# Altium schematic sheet DE-220124_02.SchDoc(DE-220124_02)
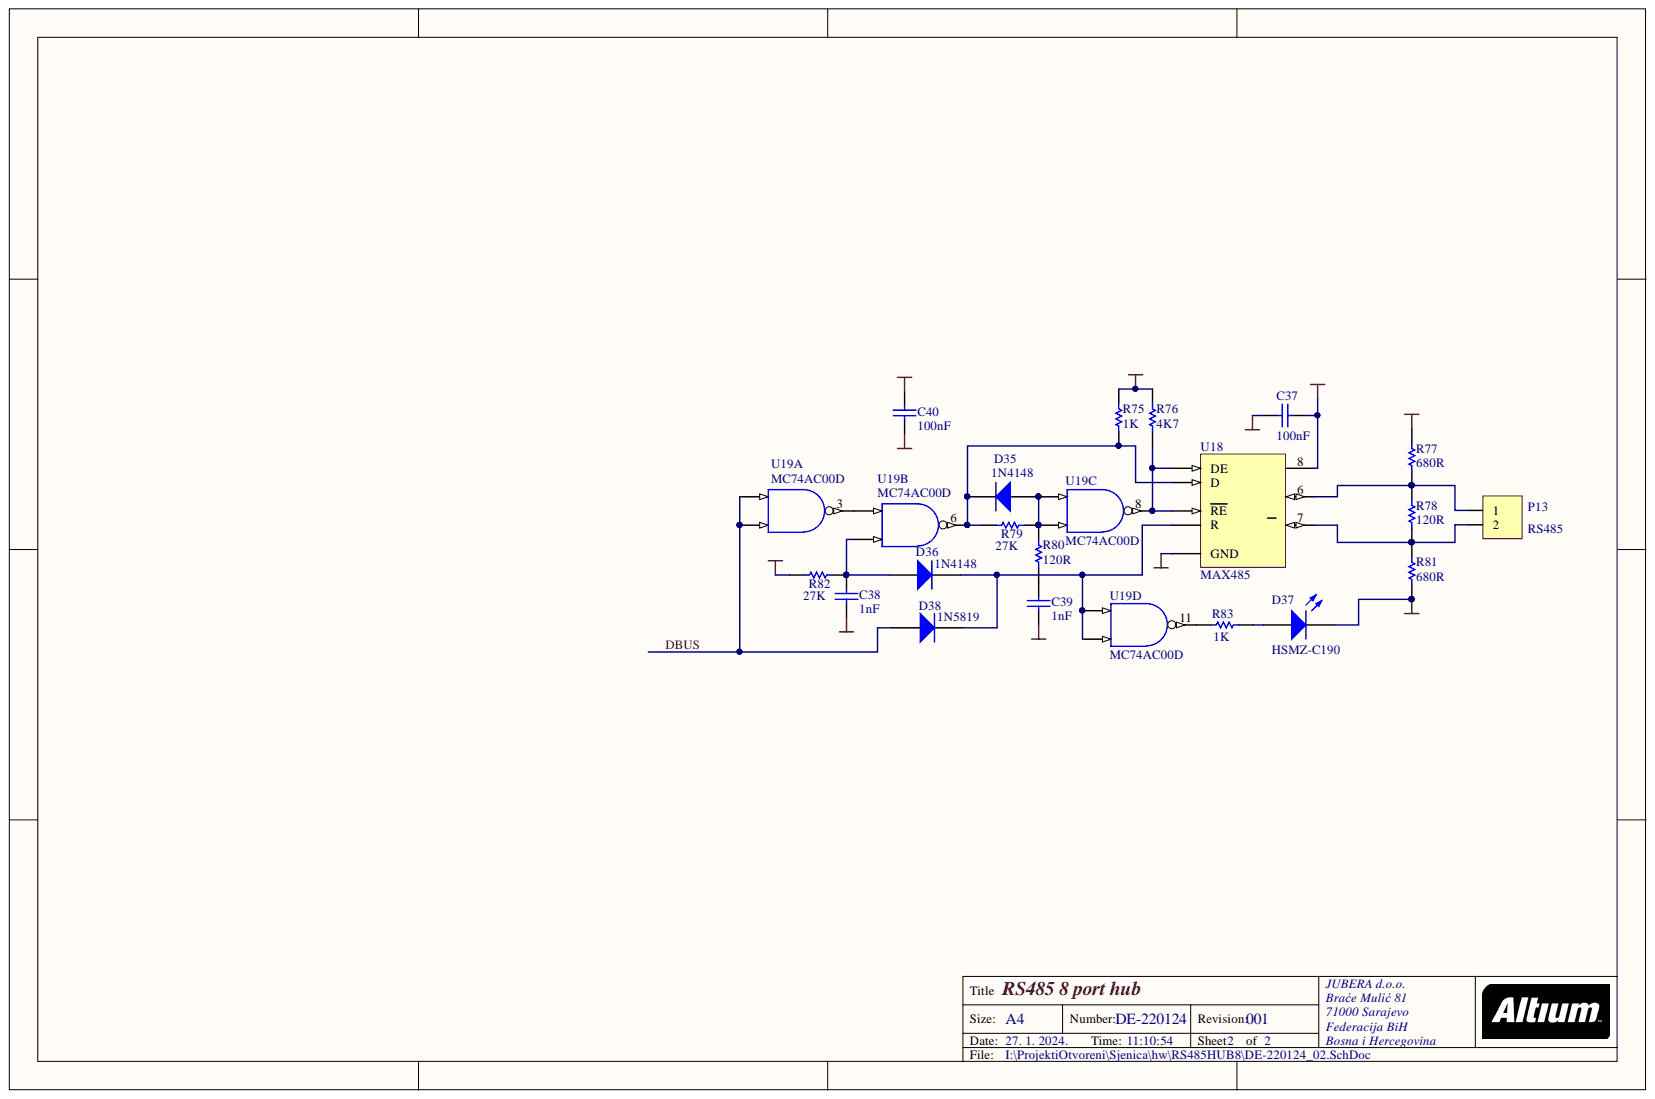
[diagram p0: DE-220124_02.SchDoc]

## Parts
C37  100nF  [0603]
C38  1nF  [0603]
C39  1nF  [0603]
C40  100nF  [0603]
D35  1N4148  [SOD323]
D36  1N4148  [SOD323]
D37  HSMZ-C190  [1.6X0.8X0.8]
D38  1N5819  [SOD323]
P13  RS485  [TERMINAL BLOK 2]
R75  1K  [ERA-0805]
R76  4K7  [ERA-0805]
R77  680R  [ERA-0805]
R78  120R  [ERA-0805]
R79  27K  [ERA-0805]
R80  120R  [ERA-0805]
R81  680R  [ERA-0805]
R82  27K  [ERA-0805]
R83  1K  [ERA-0805]
U18  MAX485  [D008_L]
U19  MC74AC00D  [751A-03_N]  (parts A,B,C,D)

## Nets
+5V: C37.2 C40.2 R75.2 R76.2 R77.2 R82.2 U18.8
GND: C37.1 C38.1 C39.1 C40.1 D37.2 R81.1 U18.5(GND) U19.7
VCC: U19.14
DBUS: D38.2 U19.1 U19.2
NetC38_2: C38.2 D36.2 R82.1 U19.5
NetC39_2: C39.2 R80.2
NetD35_1: D35.1 R75.1 R79.2 U18.4(D) U19.6
NetD35_2: D35.2 R79.1 R80.1 U19.9 U19.10
NetD36_1: D36.1 D38.1 U18.1(R) U19.12 U19.13
NetD37_1: D37.1 R83.1
NetP13_1: P13.1 R77.1 R78.2 U18.6
NetP13_2: P13.2 R78.1 R81.2 U18.7
NetR76_1: R76.1 U18.2(RE) U18.3(DE) U19.8
NetR83_2: R83.2 U19.11
NetU19_3: U19.3 U19.4

## Library data of the parts
C37-C40 = C0603: Ceramic Chip Capacitor - Standard; Note: Footprint corresponds to dimensions for bulk cassette packaging. This case size has tightened tolerances.; Simulation: CAP; Signal Integrity: C0603; Published: 17-Jul-2002; PackageReference: 0603; PackageDocument: Mar-2001; Library Name: DE-220124.SchLib; LatestRevisionNote: Silkscreen size reduced.; LatestRevisionDate: 19-Mar-2004; DatasheetDocument: Mar-2001; ComponentLink2URL: http://www.kemet.com/kemet/web/homepage/kechome.nsf/vapubfilesname/F3102TA.pdf/$file/F3102TA.pdf; ComponentLink2Description: Datasheet; ComponentLink1URL: http://www.kemet.com/; ComponentLink1Description: Manufacturer Link; Component Kind: Standard; Code_JEITA: 0603; Code_IPC: 1608 [0603]; Publisher: Altium Limited
D35 D36 D38 = BAS321: General-purpose Diode; Simulation: BAS321; Signal Integrity: BAS321; Published: 17-Sep-2003; PackageVersion: May-1999; PackageReference: SOD323; PackageDescription: SOD-323/SC-76; 2 Leads; Body 1.7 x 1.3 mm, (LxW); Library Name: DE-220124.SchLib; LatestRevisionNote: Silkscreen size reduced.; LatestRevisionDate: 19-Mar-2004; DatasheetVersion: 9-Feb-1999; ComponentLink2URL: http://www.semiconductors.philips.com/acrobat/datasheets/BAS321_2.pdf; ComponentLink2Description: Datasheet; ComponentLink1URL: http://www.semiconductors.philips.com/; ComponentLink1Description: Manufacturer Link; Component Kind: Standard; Publisher: Altium Limited
D37 = HSMZ-C190: High Performance Chip LED, Red; PCB_Layout: Complies with manufacturer's recommendation.; LuminousIntensity: 40mcd (Minimum).; Simulation: Agl_HSMZC110; Signal Integrity: LED; Published: 11-Dec-2002; PackageVersion: Mar-2002; PackageReference: 1.6X0.8X0.8; PackageDescription: SM LED; 2 Flat Leads; LED_Colour: Red; DatasheetVersion: 11-Mar-2002; ComponentLink2URL: http://cp.literature.agilent.com/litweb/pdf/5988-5891EN.pdf; ComponentLink2Description: Datasheet; ComponentLink1URL: http://www.avagotech.com/; ComponentLink1Description: Manufacturer Link; Component Kind: Standard; Publisher: Altium Limited; Library Name: Avago Optoelectronic LED.IntLib
P13 = TERMINAL BLOK 2: 2 pinski terminal blok; Library Name: DE-220124.SchLib; Component Kind: Standard
R75-R83 = ERA6Y: Rectangular Thick Film Chip Resistor, 10 Ohm to 1M Ohm Range, 0.1% and 0.5% Tolerance, 0805 Size, 0.1 W; Note: If flow soldering, adjust pad width of PCB Footprint to 0.7 - 0.8 times the width of the resistor.; Simulation: RESISTOR; Signal Integrity: Res; Published: 17-Dec-2003; PackageReference: ERA-0805; PackageDocument: Mar-2003; Library Name: Panasonic Resistor.IntLib; LatestRevisionNote: Silkscreen size reduced.; LatestRevisionDate: 19-Mar-2004; ComponentLink2URL: http://www.panasonic.com/industrial/components/pdf/AOA0000CE8.pdf; ComponentLink2Description: Datasheet; ComponentLink1URL: http://www.panasonic.com/industrial/components/resistive_products.htm; ComponentLink1Description: Manufacturer Link; Component Kind: Standard; Code_IPC: 2012 [0805]; Publisher: Altium Limited
U18 = SN75176BD: Differential Bus Transceiver; Note: Receiver Hysteresis of 50mV Typical; Published: 15-May-2002; PCB3D: D008; PackageVersion: Jan-1998; PackageReference: D008; PackageDescription: 8-Pin Small Outline Integrated Circuit 1.27 mm Pitch; Library Name: DE-220124.SchLib; LatestRevisionNote: IPC-7351 Footprint Added.; LatestRevisionDate: 13-Apr-2006; DatasheetVersion: Sep-1989; ComponentLink2URL: http://www-s.ti.com/sc/ds/sn75176b.pdf; ComponentLink2Description: Datasheet; ComponentLink1URL: http://www.ti.com/; ComponentLink1Description: Manufacturer Link; Component Kind: Standard; Code_IPC: SOIC127P600-8; Publisher: Altium Limited
U19 = MC74AC00D: Quad 2-Input NAND Gate; Signal Integrity: MC74AC00D; Published: 15-Apr-2002; PackageReference: 751A-03; PackageDescription: 14-Pin Small Outline Integrated Circuit 1.27 mm Pitch; Library Name: ON Semi Logic Gate.IntLib; LatestRevisionNote: IPC-7351 Footprint Added.; LatestRevisionDate: 13-Apr-2006; DatasheetVersion: 9-Apr-1996; ComponentLink2URL: http://www.onsemi.com/pub/Collateral/MC74AC00-D.PDF; ComponentLink2Description: Datasheet; ComponentLink1URL: http://www.onsemi.com/; ComponentLink1Description: Manufacturer Link; Component Kind: Standard; Code_JEDEC: MS-012-AB; Code_IPC: SOIC127P600-14; Publisher: Altium Limited
